# Altium schematic sheet psu.SchDoc(psu)
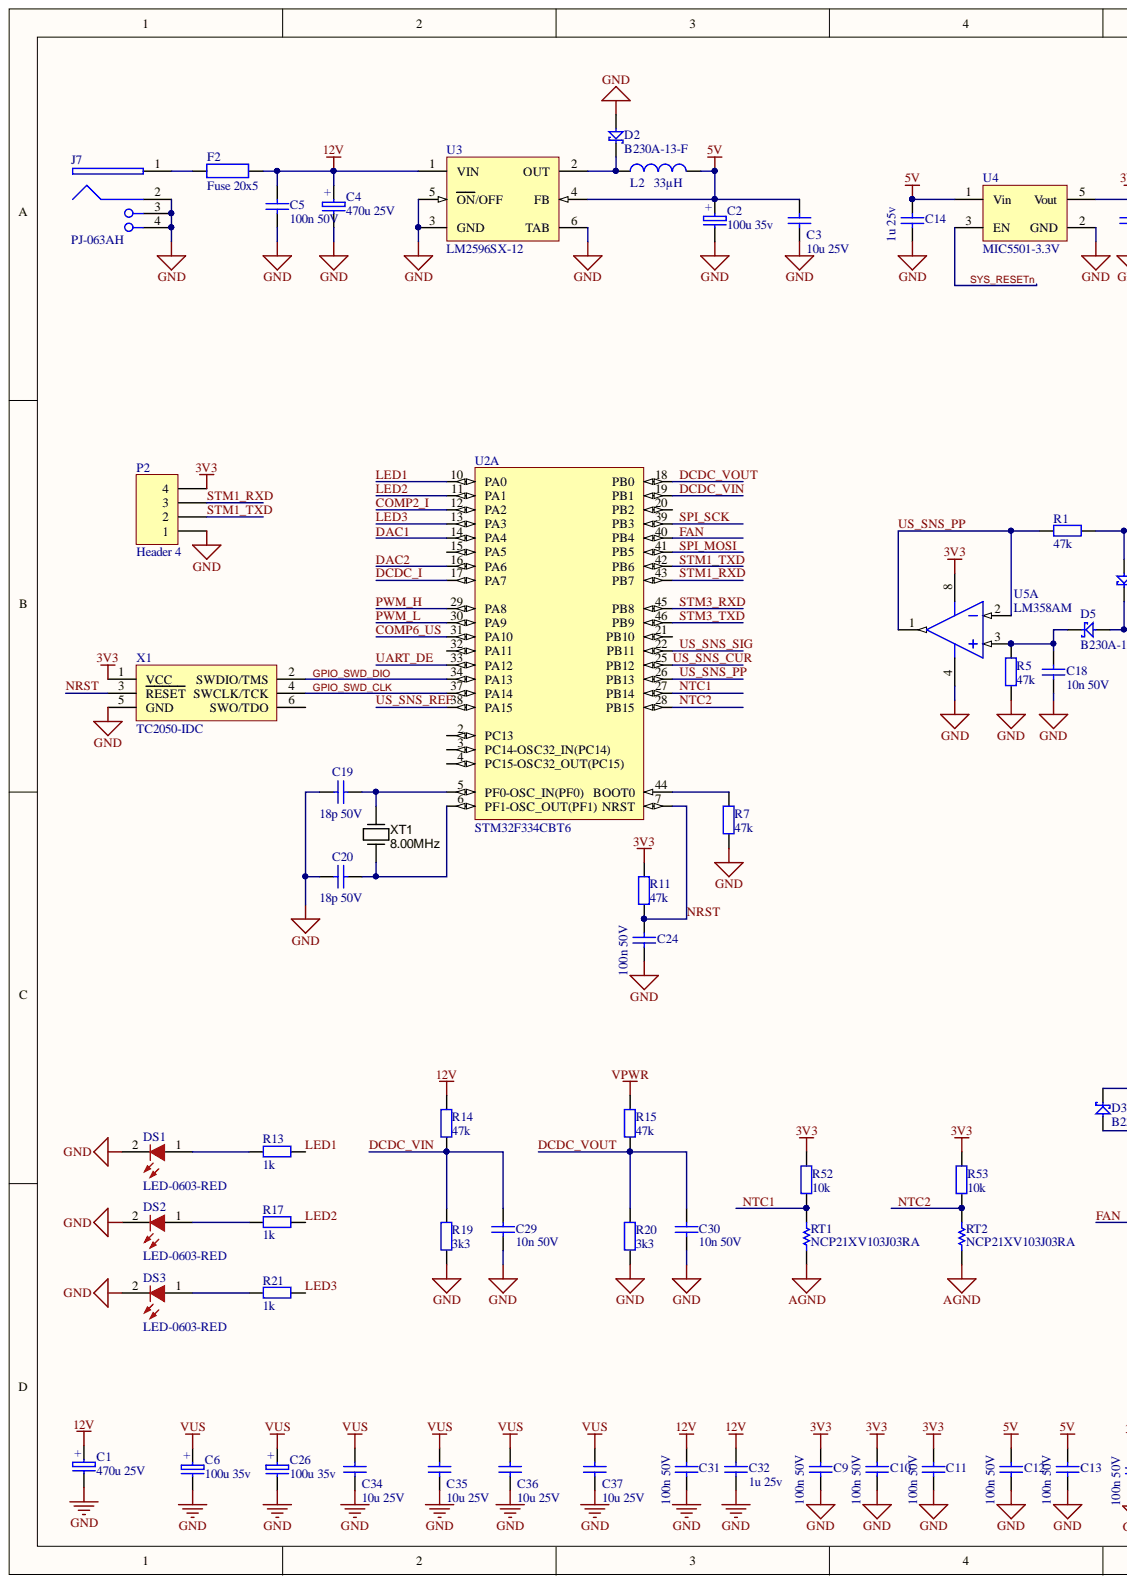
[diagram p0: psu.SchDoc, left]
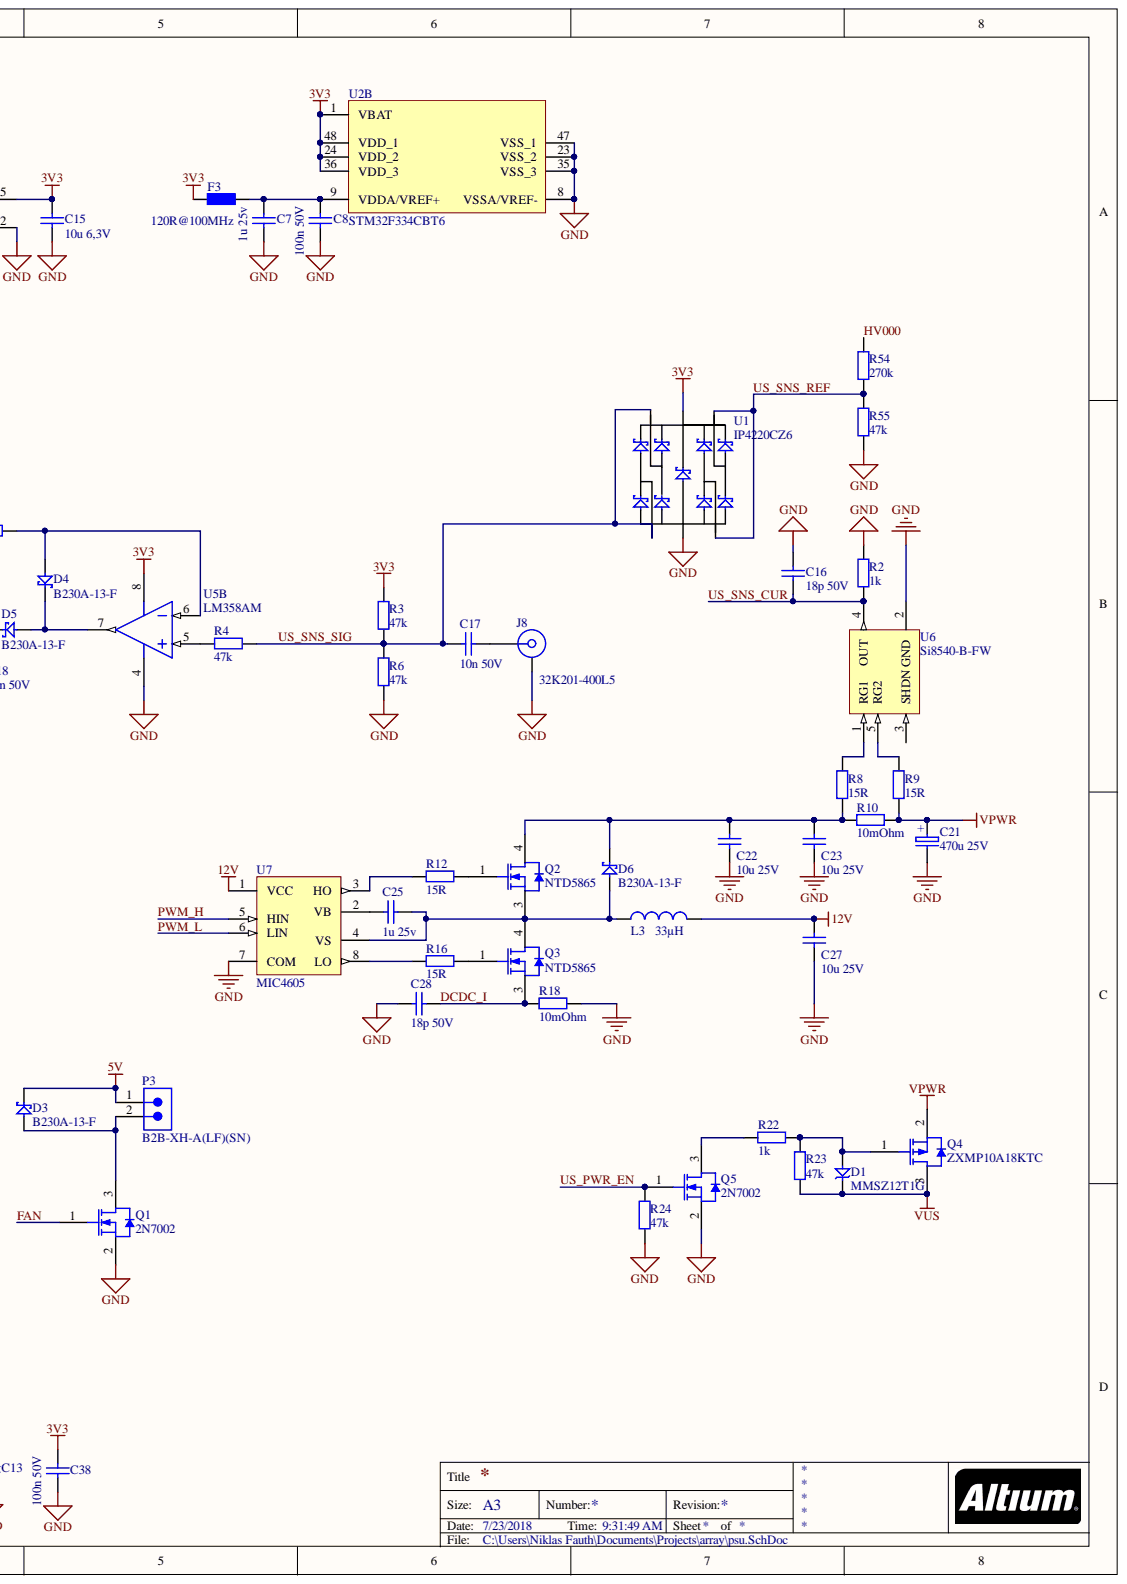
[diagram p1: psu.SchDoc, right]

## Parts
C1  470u 25V  [SMD-10x10]
C2  100u 35v  [SMD-6.3-7.7]
C3  10u 25V  [C-1206-H]
C4  470u 25V  [SMD-10x10]
C5  100n 50V  [C-0603-M]
C6  100u 35v  [SMD-6.3-7.7]
C7  1u 25v  [C-0603-H]
C8  100n 50V  [C-0603-H]
C9  100n 50V  [C-0603-H]
C10  100n 50V  [C-0603-H]
C11  100n 50V  [C-0603-H]
C12  100n 50V  [C-0603-H]
C13  100n 50V  [C-0603-H]
C14  1u 25v  [C-0603-H]
C15  10u 6,3V  [C-0603-H]
C16  18p 50V  [C-0603-H]
C17  10n 50V  [C-0603-M]
C18  10n 50V  [C-0603-M]
C19  18p 50V  [C-0603-H]
C20  18p 50V  [C-0603-H]
C21  470u 25V  [SMD-10x10]
C22  10u 25V  [C-1206-H]
C23  10u 25V  [C-1206-H]
C24  100n 50V  [C-0603-H]
C25  1u 25v  [C-0603-H]
C26  100u 35v  [SMD-6.3-7.7]
C27  10u 25V  [C-1206-H]
C28  18p 50V  [C-0603-H]
C29  10n 50V  [C-0603-M]
C30  10n 50V  [C-0603-M]
C31  100n 50V  [C-0603-H]
C32  1u 25v  [C-0603-H]
C34  10u 25V  [C-1206-H]
C35  10u 25V  [C-1206-H]
C36  10u 25V  [C-1206-H]
C37  10u 25V  [C-1206-H]
C38  100n 50V  [C-0603-H]
D1  MMSZ12T1G  [SOD123]
D2  B230A-13-F  [DIODE-SMA]
D3  B230A-13-F  [DIODE-SMA]
D4  B230A-13-F  [DIODE-SMA]
D5  B230A-13-F  [DIODE-SMA]
D6  B230A-13-F  [DIODE-SMA]
DS1  LED-0603-RED  [LED-0603-RED]
DS2  LED-0603-RED  [LED-0603-RED]
DS3  LED-0603-RED  [LED-0603-RED]
F2  Fuse 20x5  [FUSE-22.5]
F3  120R@100MHz  [R-0805-H]
J7  PJ-063AH  [CUI-PJ-063AH_V]
J8  32K201-400L5  [ROS-32K201-400L5_V]
L2  33µH  [PIS47xx]
L3  33µH  [PIS47xx]
P2  Header 4  [HDR1X4]
P3  B2B-XH-A(LF)(SN)  [JST-B2B-XH-A_V]
Q1  2N7002  [STM-SOT-23-3_V]
Q2  NTD5865  [TO-252]
Q3  NTD5865  [TO-252]
Q4  ZXMP10A18KTC  [DIOD-TO252-3_V]
Q5  2N7002  [STM-SOT-23-3_V]
R1  47k  [R-0603-H]
R2  1k  [R-0603-M]
R3  47k  [R-0603-H]
R4  47k  [R-0603-H]
R5  47k  [R-0603-H]
R6  47k  [R-0603-H]
R7  47k  [R-0603-H]
R8  15R  [R-0603-H]
R9  15R  [R-0603-H]
R10  10mOhm  [R-2512-M]
R11  47k  [R-0603-H]
R12  15R  [R-0603-H]
R13  1k  [R-0603-M]
R14  47k  [R-0603-H]
R15  47k  [R-0603-H]
R16  15R  [R-0603-H]
R17  1k  [R-0603-M]
R18  10mOhm  [R-2512-M]
R19  3k3  [R-0603-M]
R20  3k3  [R-0603-M]
R21  1k  [R-0603-M]
R22  1k  [R-0603-H]
R23  47k  [R-0603-H]
R24  47k  [R-0603-H]
R52  10k  [R-0603-M]
R53  10k  [R-0603-M]
R54  270k  [R-0603-H]
R55  47k  [R-0603-H]
RT1  NCP21XV103J03RA  [RESC2013X100X45LL20T25]
RT2  NCP21XV103J03RA  [RESC2013X100X45LL20T25]
U1  IP4220CZ6  [SOT23-6]
U2  STM32F334CBT6  [STM-LQFP48_N]  (parts A,B)
U3  LM2596SX-12  [TS5B_L]
U4  MIC5501-3.3V  [SOT23-5]
U5  LM358AM  [D0008A_N]  (parts A,B)
U6  Si8540-B-FW  [SLAB-SOT-23_N]
U7  MIC4605  [SOIC-8]
X1  TC2050-IDC  [TC2030-IDC-NL]
XT1  8.00MHz  [CRYSTAL_HC49-US-SM]

## Nets
3V3: C9.2 C10.2 C11.2 C15.2 C38.2 F3.1 P2.4 R3.1 R11.2 R52.2 R53.2 U1.5 U2.1(VBAT) U2.24(VDD_2) U2.36(VDD_3) U2.48(VDD_1) U4.5(Vout) U5.8 X1.1(VCC)
5V: C2.2 C3.2 C12.2 C13.2 C14.2 D3.2 L2.2 P3.1 U3.4(FB) U4.1(Vin)
12V: C1.2 C4.2 C5.2 C27.2 C31.2 C32.1 F2.2 L3.2 R14.2 U3.1(VIN) U7.1(VCC)
AGND: RT1.1 RT2.1
GND: C1.1 C2.1 C3.1 C4.1 C5.1 C6.1 C7.1 C8.1 C9.1 C10.1 C11.1 C12.1 C13.1 C14.1 C15.1 C16.2 C18.1 C19.2 C20.2 C21.1 C22.1 C23.1 C24.1 C26.1 C27.1 C28.1 C29.1 C30.1 C31.1 C32.2 C34.1 C35.1 C36.1 C37.1 C38.1 D2.1 DS1.2 DS2.2 DS3.2 J7.2 J7.3 J7.4 J8.MH P2.1 Q1.2 Q5.2 R2.1 R5.1 R6.2 R7.1 R18.1 R19.1 R20.1 R24.1 R55.2 U1.2 U2.8(VSSA/VREF-) U2.23(VSS_2) U2.35(VSS_3) U2.47(VSS_1) U3.3(GND) U3.5(ON/OFF) U3.6(TAB) U4.2(GND) U5.4 U6.2(GND) U7.7(COM) X1.5(GND)
VPWR: C21.2 Q4.2 R9.1 R10.1 R15.2
VUS: C6.2 C26.2 C34.2 C35.2 C36.2 C37.2 D1.2 Q4.3 R23.1
COMP2_I: U2.12(PA2)
COMP6_US: U2.31(PA10)
DAC1: U2.14(PA4)
DAC2: U2.16(PA6)
DCDC_I: C28.2 Q3.3 R18.2 U2.17(PA7)
DCDC_VIN: C29.2 R14.1 R19.2 U2.19(PB1)
DCDC_VOUT: C30.2 R15.1 R20.2 U2.18(PB0)
FAN: Q1.1 U2.40(PB4)
GPIO_SWD_CLK: U2.37(PA14) X1.4(SWCLK/TCK)
GPIO_SWD_DIO: U2.34(PA13) X1.2(SWDIO/TMS)
HV000: R54.1
LED1: R13.1 U2.10(PA0)
LED2: R17.1 U2.11(PA1)
LED3: R21.1 U2.13(PA3)
NRST: C24.2 R11.1 U2.7(NRST) X1.3(RESET)
NTC1: R52.1 RT1.2 U2.27(PB14)
NTC2: R53.1 RT2.2 U2.28(PB15)
PWM_H: U2.29(PA8) U7.5(HIN)
PWM_L: U2.30(PA9) U7.6(LIN)
SPI_MOSI: U2.41(PB5)
SPI_SCK: U2.39(PB3)
STM1_RXD: P2.3 U2.43(PB7)
STM1_TXD: P2.2 U2.42(PB6)
STM3_RXD: U2.45(PB8)
STM3_TXD: U2.46(PB9)
SYS_RESETn: U4.3(EN)
UART_DE: U2.33(PA12)
US_PWR_EN: Q5.1 R24.2
US_SNS_CUR: C16.1 R2.2 U2.25(PB12) U6.4(OUT)
US_SNS_PP: R1.2 U2.26(PB13) U5.1 U5.2
US_SNS_REF: R54.2 R55.1 U1.3 U1.4 U2.38(PA15)
US_SNS_SIG: C17.2 R3.2 R4.1 R6.1 U1.1 U1.6 U2.22(PB11)
NetC7_2: C7.2 C8.2 F3.2 U2.9(VDDA/VREF+)
NetC17_1: C17.1 J8.1
NetC18_2: C18.2 D5.2 R5.2 U5.3
NetC19_1: C19.1 U2.5(PF0-OSC_IN(PF0)) XT1.2
NetC20_1: C20.1 U2.6(PF1-OSC_OUT(PF1)) XT1.1
NetC22_2: C22.2 C23.2 D6.2 Q2.4 R8.1 R10.2
NetC25_1: C25.1 D6.1 L3.1 Q2.3 Q3.4 U7.4(VS)
NetC25_2: C25.2 U7.2(VB)
NetD1_1: D1.1 Q4.1 R22.2 R23.2
NetD2_2: D2.2 L2.1 U3.2(OUT)
NetD3_1: D3.1 P3.2 Q1.3
NetD4_1: D4.1 R1.1 U5.6
NetD4_2: D4.2 D5.1 U5.7
NetDS1_1: DS1.1 R13.2
NetDS2_1: DS2.1 R17.2
NetDS3_1: DS3.1 R21.2
NetF2_1: F2.1 J7.1
NetQ2_1: Q2.1 R12.2
NetQ3_1: Q3.1 R16.2
NetQ5_3: Q5.3 R22.1
NetR4_2: R4.2 U5.5
NetR7_2: R7.2 U2.44(BOOT0)
NetR8_2: R8.2 U6.1(RG1)
NetR9_2: R9.2 U6.5(RG2)
NetR12_1: R12.1 U7.3(HO)
NetR16_1: R16.1 U7.8(LO)

Not connected: U2 2(PC13) 3(PC14-OSC32_IN(PC14)) 4(PC15-OSC32_OUT(PC15)) 15(PA5) 20(PB2) 21(PB10) 32(PA11); U6 3(SHDN); X1 6(SWO/TDO)

## Library data of the parts
C1 C4 C21 = CE-SMD-470u-25V: electrolytic capacitor 470u 25V; Supplier Part Number 1: 647-UWD1E471MCL1; Supplier 1: Mouser; Library Name: Passives.IntLib; Component Kind: Standard
C2 C6 C26 = CE-SMD-100u-35V: electrolytic capacitor 100uF 35V; Supplier Part Number 1: 647-UWT1V101MCL1S; Supplier 1: Mouser; Library Name: Passives.IntLib; Component Kind: Standard
C3 C22 C23 C27 C34-C37 = C-1206-10u-25V: Capacitor 10uF 25V; Supplier Part Number 1: 80-C1206C106K3P; Supplier 1: Mouser; Library Name: Passives.IntLib; Component Kind: Standard
C5 C8-C13 C24 C31 C38 = C-0603-100n-50V: Capacitor 100n 50V; Supplier Part Number 1: 80-C0603C104K5RAUTO; Supplier 1: Mouser; Library Name: Passives.IntLib; Component Kind: Standard
C7 C14 C25 C32 = C-0603-1u-25V: Capacitor 1uF 25V; Supplier Part Number 1: 80-C0603C105K3R; Supplier 1: Mouser; Library Name: Passives.IntLib; Component Kind: Standard
C15 = C-0603-10u-6,3V: Capacitor 10uF 6,3V; Supplier Part Number 1: 80-C0603C106M9P; Supplier 1: Mouser; Library Name: Passives.IntLib; Component Kind: Standard
C16 C19 C20 C28 = C-0402-18p-50V: Capacitor 18p 50V; Supplier Part Number 1: 80-C0402C180J5G; Supplier 1: Mouser; Library Name: Passives.IntLib; Component Kind: Standard
C17 C18 C29 C30 = C-0603-10n-50V: Capacitor 10nF 50V; Supplier Part Number 1: 80-C0603C103K5RAC; Supplier 1: Mouser; Library Name: Passives.IntLib; Component Kind: Standard
D1 = Diode-Zener-12V: Supplier Part Number 1: 863-MMSZ12T1G; Supplier 1: Mouser; Library Name: advanced.IntLib; Component Kind: Standard
D2-D6 = D-B230A-13-F: Supplier Part Number 1: 621-B230A-F; Supplier 1: Mouser; Library Name: advanced.SchLib; Description: B230A-13-F; Component Kind: Standard
F2 = FUSE-20x5: Supplier Part Number 1: 693-0031.8201; Supplier 1: Mouser; Library Name: advanced.IntLib; Component Kind: Standard
F3 = F-0805-120R@100mhz: Ferrite 120R@100MHz; Supplier Part Number 1: 81-DLW21HN121SQ2L; Supplier 1: Mouser; Library Name: Passives.IntLib; Component Kind: Standard
J7 = CMP-2000-06898-1: Through Hole Right Angle DC Power Jack, 8 A, 2 mm Center Pin, 2 Position, -25 to 85 degC, RoHS, Bulk; Manufacturer: CUIInc.; Voltage Rating: 24V; Outside Contact Diameter: 6.5mm; Insulation Resistance: 100MOhms; Inside Contact Diameter: 2mm; Current Rating: 8A; Brand: CUI; Termination Style: ThroughHole; RoHS: True; Product Category: DCPowerConnectors; Product: Jacks; Package Reference: PJ-063AH; Package Description: 2-Pin Through Hole Device, Body 13 x 10 mm, Height 9 mm; Operating Temperature Range: -25Cto+85C; Mounting Technology: ThroughHole; Mounting Style: KinkedPin; Mounting Angle: Right; Manufacturer URL: http://www.cui.com/; Library Name: Altium Content Vault; Housing Material: PolybutyleneTerephthalate(PBT); Flammability Rating: UL94V-0; Factory Pack Quantity: 500; Datasheet Version: Rev. 1.02, 03/2016; Datasheet URL: http://www.cui.com/product/resource/pj-063ah.pdf; Contact Plating: Nickel; Contact Material: Copper; Contact Gender: Male; Connector Type: DCPowerJack; Component Kind: Standard
J8 = CMP-0751-00005-1: SMA Right Angle Jack, Up to 18 GHz, -65 to 165 degC; Manufacturer: Rosenberger; Design Note: Footprint in library is a generic example - only application specific footprint delivers High Performance; PartNumber: 32K201-400L5; PackageVersion: a00; PackageReference: 32K201-400L5; PackageDescription: SMA Right Angle Jack, 14.8 x 6.35 x 9.6 mm; Mounting Technology: Through Hole; Library Name: Altium Content Vault; DatasheetVersion: a00; ComponentLink5URL: http://www.rosenberger.com/en/contact/sales/; ComponentLink5Description: Webshop / RFQ; ComponentLink4URL: http://rosenberger.de/ok/images/documents/spez/32.pdf; ComponentLink4Description: Series Overview / Design Note; ComponentLink3URL: http://rosenberger.de/ok/images/documents/db/32K201-400L5.pdf; ComponentLink3Description: Package Specification; ComponentLink2URL: http://rosenberger.de/ok/images/documents/db/32K201-400L5.pdf; ComponentLink2Description: Datasheet; ComponentLink1URL: http://www.rosenberger.de; ComponentLink1Description: Manufacturer URL; Component Kind: Standard
L2 L3 = L-PIS4728: PIS4728; Library Name: advanced.IntLib; Component Kind: Standard
P2 = Header 4: Header, 4-Pin; Signal Integrity: Connector; Library Name: Miscellaneous Connectors.IntLib; LatestRevisionNote: Re-released for DXP Platform.; LatestRevisionDate: 17-Jul-2002; Component Kind: Standard; Publisher: Altium Limited
P3 = CMP-2000-05888-1: Male Header, Pitch 2.5 mm, 1 x 2 Position, Height 7 mm, Tail Length 3.4 mm, -25 to 85 degC, RoHS, Bulk; Manufacturer: JST; Style/Orientation: StraightHeader/Plug; Pitch: 2.5mm; No of Positions: 2; Type: ShroudedHeader; Termination: Crimp; Package Reference: B2B-XH-A; Package Description: Male Header, Pitch 2.5 mm, 1 x 2 Position, Height 7 mm, Tail Length 3.4 mm; Mounting Technology: ThroughHole; Manufacturer URL: http://www.jst.com/home2.html; Library Name: Altium Content Vault; Datasheet Version: 05/2016; Datasheet URL: http://www.jst-mfg.com/product/pdf/eng/eXH.pdf; Component Kind: Standard
Q1 Q5 = CMP-0434-00001-1: N-channel 60 V, 1.8 Ohm, 0.35 A STripFET(TM) II Power MOSFET, SOT23; Manufacturer: STMicroelectronics; PartNumber: 2N7002; PackageReference: SOT-23; PackageDescription: 3-Pin SOT23, 3.016 x 2.8 mm Body, 0.95 mm Pitch; Mounting Technology: Surface Mount; Library Name: Altium Content Vault; DatasheetVersion: Rev. 9, 11/2008; ComponentLink2URL: http://www.st.com/st-web-ui/static/active/en/resource/technical/document/datasheet/CD00005134.pdf; ComponentLink2Description: Datasheet; ComponentLink1URL: http://www.st.com/; ComponentLink1Description: Manufacturer URL; Component Kind: Standard
Q2 Q3 = MOSFET-IPD60R400: IPD60R400; Supplier Part Number 1: IPD60R400CEATMA1; Supplier 1: Mouser; Component Kind: Standard; Library Name: erleuchtung.IntLib
Q4 = CMP-1667-00002-2: P-Channel Enhancement Mode MOSFET, -100 V, -3.8 A, -55 to 150 degC, 3-Pin DPAK (TO252), RoHS, Tape and Reel; Manufacturer: Diodes Inc; RoHSCompliant: true; REACH SVHC: No SVHC; Pins: 3; Packaging: Tape and Reel; PackageReference: TO252-3; Package Quantity: 2500; PackageDescription: 3-Pin Transistor Outline, Body 6.1 x 6.575 mm, Pitch 2.286 mm; Mounting Technology: Surface Mount; Mount: Surface Mount; Min Operating Temperature: -55 degC; Max Operating Temperature: 150 degC; Library Name: Altium Content Vault; Length: 6.73 mm; Height: 2.39 mm; DatasheetVersion: Rev. 2-2, 08/2014; ComponentLink2URL: http://www.diodes.com/_files/datasheets/ZXMP10A18K.pdf; ComponentLink2Description: Datasheet; ComponentLink1URL: http://www.diodes.com/; ComponentLink1Description: Manufacturer URL; Component Kind: Standard
R1 R3-R7 R11 R14 R15 R23 R24 R54 R55 = R-0603-47k: Resistor 4k7; Supplier Part Number 1: 71-CRCW060347K0FKEB; Supplier 1: Mouser; Library Name: Passives.IntLib; Component Kind: Standard
R2 R13 R17 R21 R22 = R-0603-1k: Resistor 1k; Supplier Part Number 1: 71-CRCW0603-1.0K-E3; Supplier 1: Mouser; Library Name: Passives.IntLib; Component Kind: Standard
R8 R9 R12 R16 = R-0603-4k7: Resistor 4k7; Supplier Part Number 1: 71-CRCW0603-4.7K-E3; Supplier 1: Mouser; Library Name: Passives.IntLib; Component Kind: Standard
R10 R18 = R-2512-0.1: Resistor 0.1; Supplier 1: Mouser; Component Kind: Standard; Library Name: erleuchtung.IntLib
R19 R20 = R-0603-5k1: Resistor 5.1k; Supplier Part Number 1: 71-CRCW0603-5.1K-E3; Supplier 1: Mouser; Library Name: Passives.IntLib; Component Kind: Standard
R52 R53 = R-0603-10k: Resistor 10k; Supplier Part Number 1: 71-CRCW0603-10K-E3; Supplier 1: Mouser; Library Name: Passives.IntLib; Component Kind: Standard
RT1 RT2 = CMP-2000-06827-1: Chip Thermistor, 10 KOhm, +/- 5%, 200 mW, -40 to 125 degC, 0805 (2012 Metric), RoHS, Tape and Reel; Manufacturer: Murata; Resistance: 10kO; Rated Current: 0.44mA; B-constant: 3934K; Type: NTC; Tolerance (%): ±5%; Temperature Range: -40to+125°C; Package Reference: 0805; Package Description: 2-Pin Surface Mount Device, Body 2 x 1.25 mm; Mounting Technology: SurfaceMount; Manufacturer URL: http://www.murata.com/; Library Name: Altium Content Vault; Datasheet Version: 12/2014; Datasheet URL: http://www.murata.com/~/media/webrenewal/support/library/catalog/products/thermistor/ntc/r44e.ashx; Component Kind: Standard
U1 = IP4220CZ6: USB ESD Protection; Component Kind: Standard; Library Name: gpn-badge.SCHLIB
U2 = CMP-0572-00016-2: ARM Cortex-M4 32-bit MCU, 128 KB Flash, 24 KB Internal RAM, 37 I/Os, 48-pin LQFP, -40 to 85 degC, Tray; PartNumber: STM32F302CBT6; Supply Voltage(Vcc) min (V): 2; Operating Frequency(F) (Processor speed) (MHz): 72; Internal RAM Size (kB): 24; I/Os (High Current): 37; FLASH Size (Prog) (kB): 128; D/A Converter: 1x12-bit (1 Channel); PartId: 1; Packing: Tray; PackageVersion: Rev. 6, 06/2013; PackageReference: LQFP48; PackageDescription: 48-Pin Low Profile Quad Flat Package, 7 x 7 mm Body, 0.5 mm Pitch; Mounting Technology: Surface Mount; Library Name: Altium Content Vault; DatasheetVersion: Rev. 6; Core: ARM Cortex-M4; ComponentLink3URL: http://www.st.com/st-web-ui/static/active/en/resource/technical/document/datasheet/DM00058181.pdf; ComponentLink3Description: Package Specification; ComponentLink2URL: http://www.st.com/st-web-ui/static/active/en/resource/technical/document/datasheet/DM00058181.pdf; ComponentLink2Description: Datasheet; ComponentLink1URL: http://www.st.com/web/en/home.html; ComponentLink1Description: Manufacturer URL; Component Kind: Standard
U3 = CMP-0069-00277-2: SIMPLE SWITCHER® Power Converter 150 KHz 3A Step-Down Voltage Regulator, 5-pin TO-263; Manufacturer: National Semiconductor; PowerWise: N; PackageTopMarking: NSUZXYTTE#*LM2596S*-12 P+; RoHS: N; RevisionNote: Component URLs added; PinCount: 5; PackageVersion: revD, Apr-2005; PackageReference: TS5B; PackageDescription: TO-263, Molded, .400 x .390 x .180in, 5 Lead, .067in Pitch, Surface Mount; Library Name: Altium Content Vault; DatasheetVersion: May-2002; CompType: Switcher; ComponentLink3URL: http://www.national.com/packaging/folders/ts5b.html; ComponentLink3Description: TS5B Package Page; ComponentLink2URL: http://www.national.com/pf/LM/LM2596.html; ComponentLink2Description: LM2596 Web Page; ComponentLink1URL: http://www.national.com/ds/LM/LM2596.pdf; ComponentLink1Description: LM2596 Datasheet; Component Kind: Standard; Code_JEDEC: MO-169-AB
U5 = CMP-0055-00796-3: Low Power Dual Operational Amplifier, 8-pin Narrow SOIC; Manufacturer: Texas Instruments; PowerWise: N; PackageTopMarking: NSZXTT*LM*358AM; CompType: Amplifiers; RoHS: N; PinCount: 8; PartId: 1; Package Reference: D0008A; PackageDescription: SOIC Narrow, 4.9 x 3.9 x 1.45mm, 8 Lead, 1.27mm Pitch; Library Name: Altium Content Vault; ComponentLink1URL: http://www.ti.com/general/docs/lit/getliterature.tsp?genericPartNumber=LM358-N&fileType=pdf; ComponentLink1Description: Datasheet URL; Component Kind: Standard; Code_JEDEC: MS-012-AA; Code_IPC: SOIC127P600-8
U6 = CMP-0512-00420-1: High-Side Current Sense Amplifier, 0.1 to 10 A Full Scale Current, -40 to 85 degC, 5-pin SOT23; Manufacturer: Silicon Labs; Sub-Family: Current Sensors; Initial Accuracy: 0.2%; Full Scale Current: 0.1 - 10 A; PartNumber: Si8540-B-FW; PackageReference: SOT-23; PackageDescription: 5-Pin Plastic SOT-23, Body 2.9 x 1.6 mm, Pitch 0.95 mm; Output Mode: Current; Mounting Technology: Surface Mount; Library Name: Altium Content Vault; DatasheetVersion: Rev. 1.2; ComponentLink3URL: http://www.silabs.com/Support%20Documents/TechnicalDocs/Si8540.pdf; ComponentLink3Description: Package Specification; ComponentLink2URL: http://www.silabs.com/Support Documents/TechnicalDocs/Si8540.pdf; ComponentLink2Description: Datasheet; ComponentLink1URL: http://www.silabs.com/; ComponentLink1Description: Manufacturer URL; Component Kind: Standard; Code_JEDEC: MO-178; Code_IPC: J-STD-020D
X1 = TAG-Connect-SWD: TC2050-IDC SWD; Library Name: advanced.SchLib; Component Kind: Standard
XT1 = Crystal_6MHz_HC49/US: Resonator Ceramic 6.00 MHz 30ppm; Manufacturer Part Number 1: ATS060BSM-1; Manufacturer 1: CTS-Frequency Controls; SCDType: spec; Parameter Name: 0.169" (4.30mm); Operating Mode: Fundamental; Load Capacitance: 18pF; Frequency Stability: ±50ppm; Frequency: 6MHz; Type: MHz Crystal; Supplier Part Number 1: CTX882CT-ND; Supplier 1: Digi-Key; Size / Dimension: 0.437" L x 0.190" W (11.10mm x 4.83mm); Ratings: 6.00 MHz; Package / Case: HC49/US-SM; Operating Temperature: -20°C ~ 70°C; Mounting Type: Surface Mount; Library Name: PBL - Resonators.SCHLIB; Frequency Tolerance: ±30ppm; ComponentLink1URL: http://www.ctscorp.com/components/Datasheets/008-0309-0.pdf; ComponentLink1Description: http://www.ctscorp.com/components/Datasheets/008-0309-0.pdf; Component Kind: Standard
DS1-DS3 = LED-0603-RED: Supplier Part Number 1: 859-LTST-C190EKT; Supplier 1: Mouser; Library Name: advanced.SchLib; Component Kind: Standard
U4 = MIC5501-3.3V: Supplier Part Number 1: 998-MIC5501-3.0YM5TR; Supplier 1: Mouser; Library Name: advanced.IntLib; Component Kind: Standard
U7 = MIC4605: Library Name: advanced.SchLib; Component Kind: Standard
